# Altium schematic sheet No.2 运放板.SchDoc("No.2 运放板")
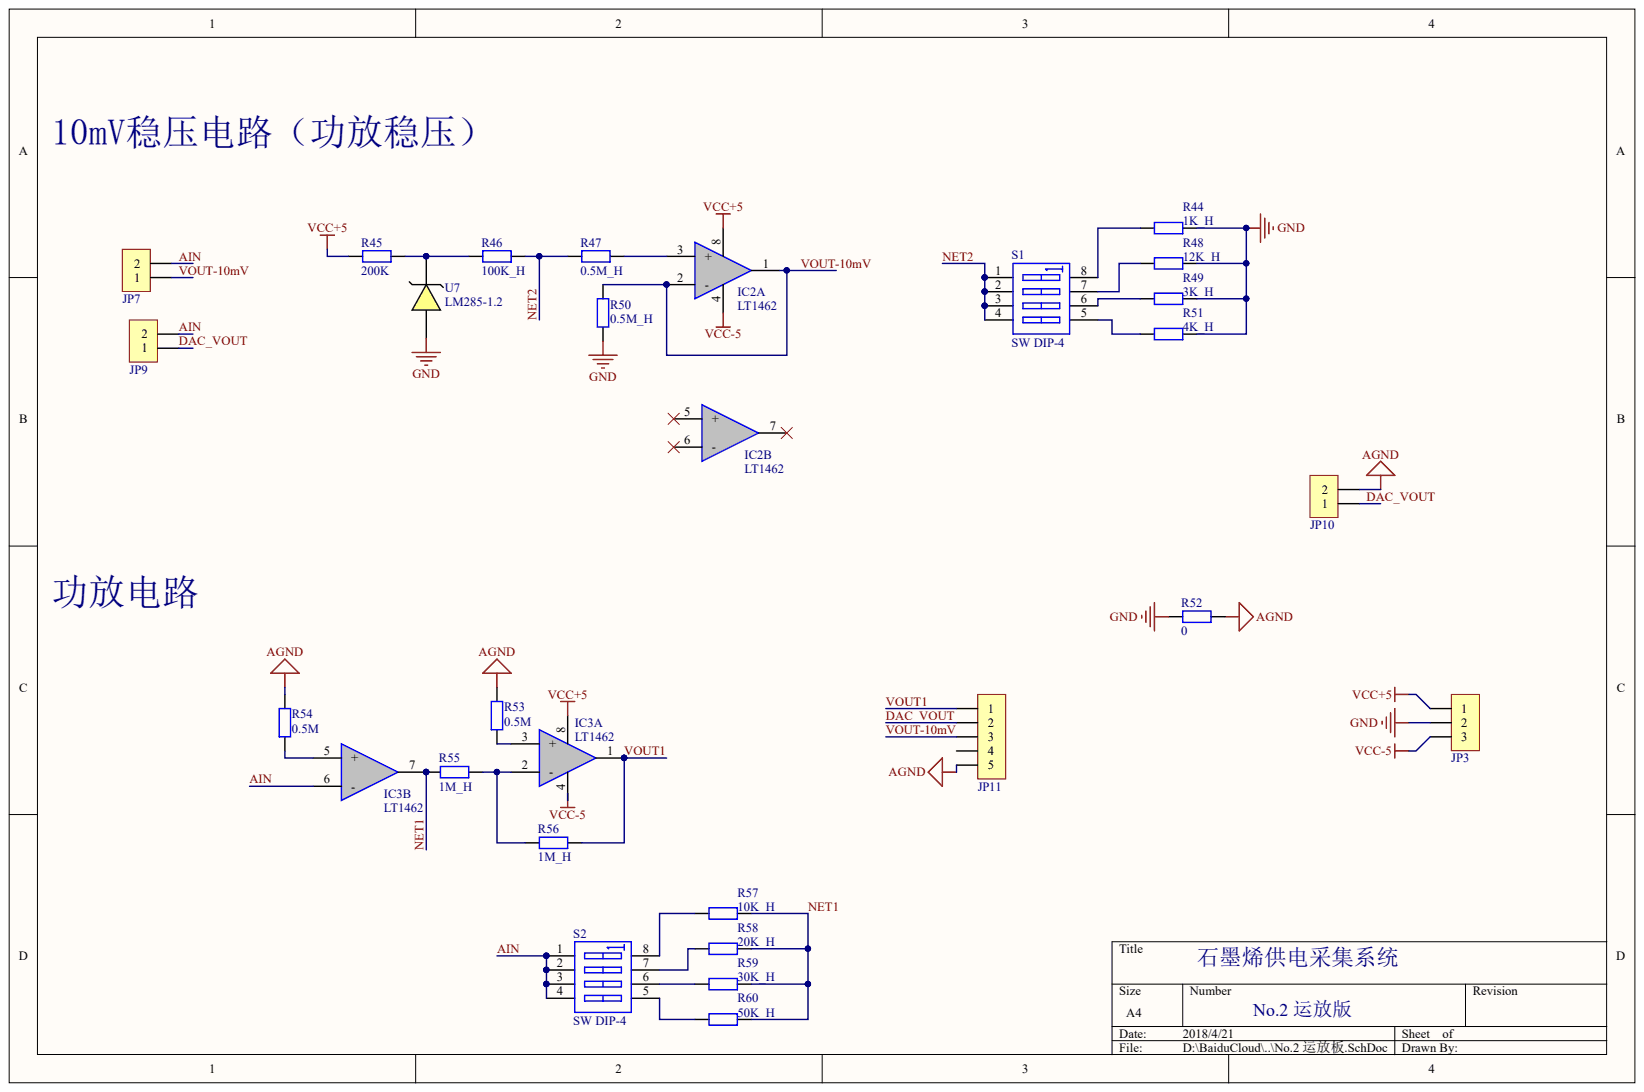
[diagram p0: No.2 运放板.SchDoc]

## Parts
IC2  LT1462  (parts A,B)
IC3  LT1462  (parts A,B)
JP3  HDR-1X3  (value not drawn)
JP7  HDR-1X2  (value not drawn)
JP9  HDR-1X2  (value not drawn)
JP10  HDR-1X2  (value not drawn)
JP11  HDR-1X5  (value not drawn)
R44  1K_H
R45  200K
R46  100K_H
R47  0.5M_H
R48  12K_H
R49  3K_H
R50  0.5M_H
R51  4K_H
R52  0
R53  0.5M
R54  0.5M
R55  1M_H
R56  1M_H
R57  10K_H
R58  20K_H
R59  30K_H
R60  50K_H
S1  SW DIP-4
S2  SW DIP-4
U7  LM285-1.2

## Nets
AGND: JP10.2 JP11.5 R52.2 R53.1 R54.1
GND: JP3.2 R44.2 R48.2 R49.2 R50.1 R51.2 R52.1 U7.3
VCC+5: IC2.8 IC3.8 JP3.1 R45.1
VCC-5: IC2.4 IC3.4 JP3.3
AIN: IC3.6(-) JP7.2 JP9.2 S2.1 S2.2 S2.3 S2.4
DAC_VOUT: JP9.1 JP10.1 JP11.2
NET1: IC3.7 R55.1 R57.2 R58.2 R59.2 R60.2
NET2: R46.2 R47.1 S1.1 S1.2 S1.3 S1.4
VOUT1: IC3.1 JP11.1 R56.2
VOUT-10MV: IC2.1 IC2.2(-) JP7.1 JP11.3 R50.2
NetIC2_3: IC2.3(+) R47.2
NetIC3_2: IC3.2(-) R55.2 R56.1
NetIC3_3: IC3.3(+) R53.2
NetIC3_5: IC3.5(+) R54.2
NetR44_1: R44.1 S1.8
NetR45_2: R45.2 R46.1 U7.2
NetR48_1: R48.1 S1.7
NetR49_1: R49.1 S1.6
NetR51_1: R51.1 S1.5
NetR57_1: R57.1 S2.8
NetR58_1: R58.1 S2.7
NetR59_1: R59.1 S2.6
NetR60_1: R60.1 S2.5

Not connected: IC2 5(+) 6(-) 7; JP11 4

## Library data of the parts
IC2 IC3 = (no symbol name): BOM名称: 双运放; 版权归属: 源创客; 学习资源: www.ooocke.com
JP3 = (no symbol name): BOM名称: 3P接插件; 版权归属: 源创客; 学习资源: www.ooocke.com
JP7 JP9 JP10 = (no symbol name): BOM名称: 2P接插件; 版权归属: 源创客; 学习资源: www.ooocke.com
JP11 = (no symbol name): BOM名称: 5P接插件; 版权归属: 源创客; 学习资源: www.ooocke.com
R44-R60 = (no symbol name): BOM名称: 贴片电阻; 版权归属: 源创客; 学习资源: www.ooocke.com
S1 S2 U7 = (no symbol name)
  S1 S2: Published: 24-Mar-1999; LatestRevisionDate: 17-Jul-2002; LatestRevisionNote: Re-released for DXP Platform.; PackageReference: SO8; Code_JEDEC: MS-012-AA; Code_IPC: SO8; Publisher: Altium Limited
